# Altium schematic sheet ADC_I.SchDoc
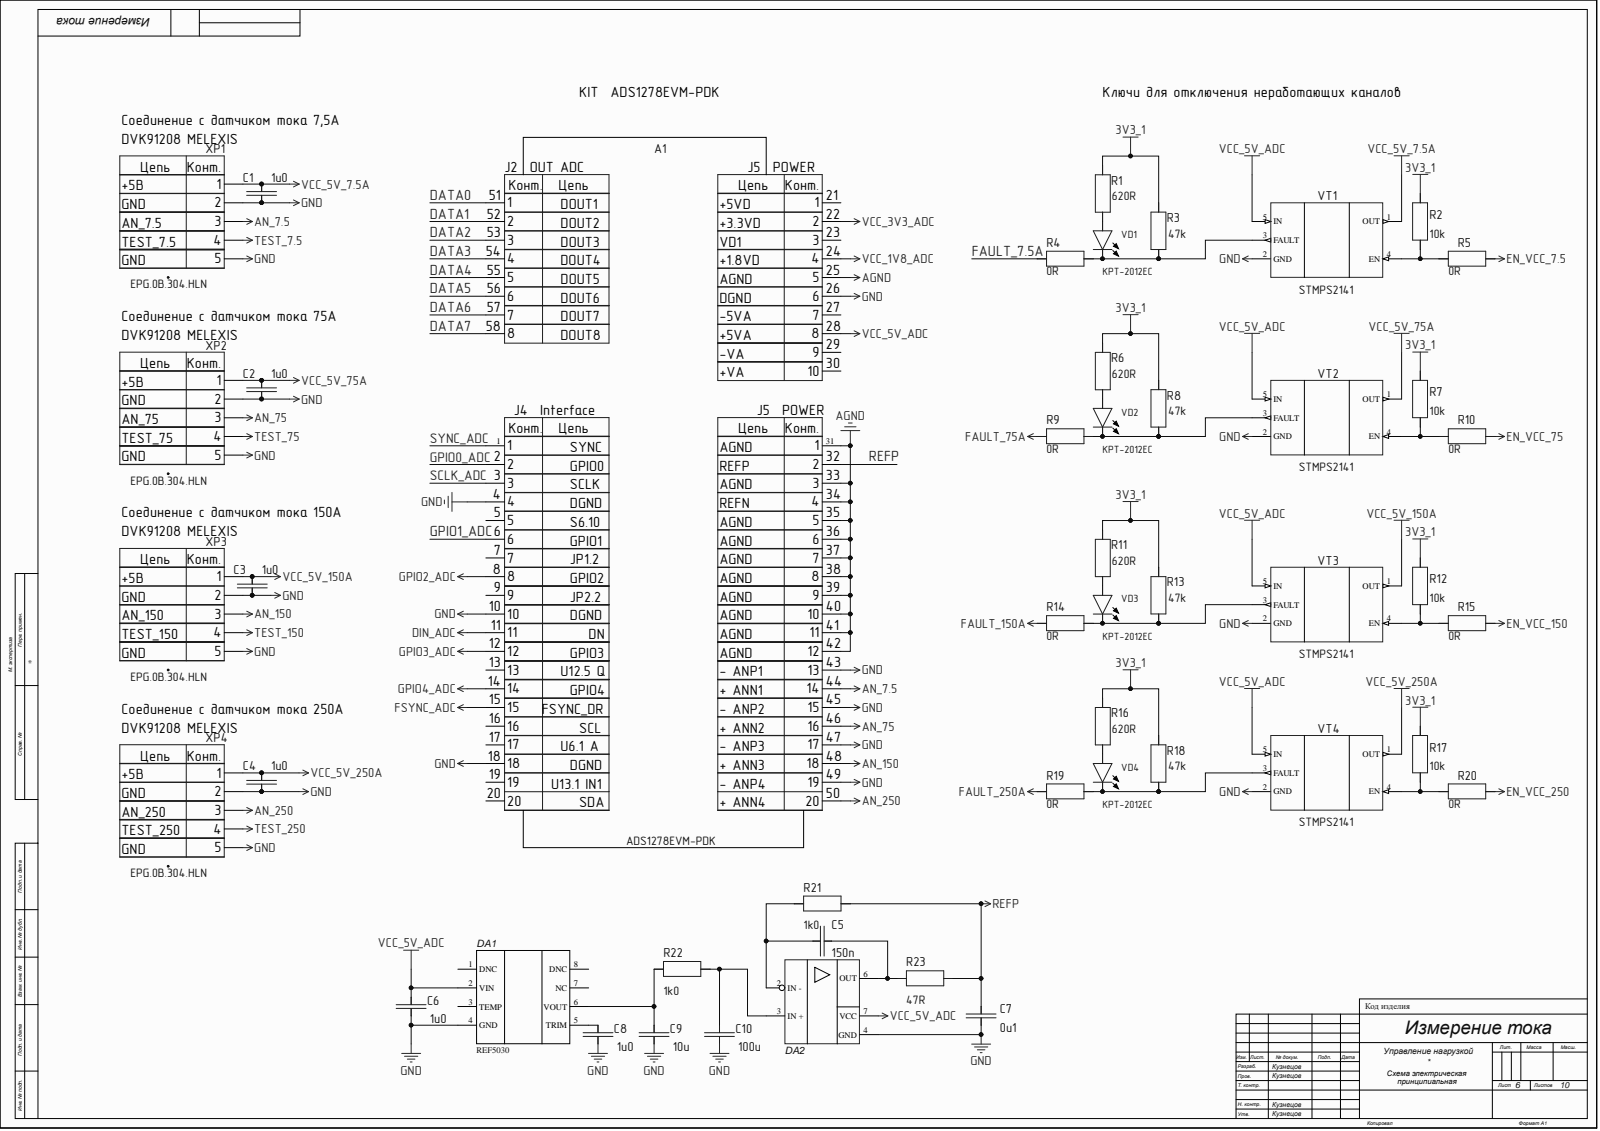
[diagram p0: ADC_I.SchDoc]

## Parts
A1  ADS1278EVM-PDK  [ADS1278EVM-PDK]
C1  1u0
C2  1u0
C3  1u0
C4  1u0
C5  150n
C6  1u0
C7  0u1
C8  1u0
C9  10u
C10  100u
DA1  REF5030  [SO-8]
DA2  OPA350  [SO-8]  (value not drawn)
R1  620R  [0805]
R2  10k  [0805]
R3  47k  [0805]
R4  0R  [0805]
R5  0R  [0805]
R6  620R  [0805]
R7  10k  [0805]
R8  47k  [0805]
R9  0R  [0805]
R10  0R  [0805]
R11  620R  [0805]
R12  10k  [0805]
R13  47k  [0805]
R14  0R  [0805]
R15  0R  [0805]
R16  620R  [0805]
R17  10k  [0805]
R18  47k  [0805]
R19  0R  [0805]
R20  0R  [0805]
R21  1k0  [0805]
R22  1k0  [0805]
R23  47R  [0805]
VD1  KPT-2012EC  [0805_LED_RED]
VD2  KPT-2012EC  [0805_LED_RED]
VD3  KPT-2012EC  [0805_LED_RED]
VD4  KPT-2012EC  [0805_LED_RED]
VT1  STMPS2141  [SOT-23-5]
VT2  STMPS2141  [SOT-23-5]
VT3  STMPS2141  [SOT-23-5]
VT4  STMPS2141  [SOT-23-5]
XP1  EPG.0B.304.HLN  [LEMO_EPG.0B.304.HLN]
XP2  EPG.0B.304.HLN  [LEMO_EPG.0B.304.HLN]
XP3  EPG.0B.304.HLN  [LEMO_EPG.0B.304.HLN]
XP4  EPG.0B.304.HLN  [LEMO_EPG.0B.304.HLN]

## Nets
3V3_1: R1.2 R2.1 R3.1 R6.2 R7.1 R8.1 R11.2 R12.1 R13.1 R16.2 R17.1 R18.1
AGND: A1.25 A1.31 A1.33 A1.34 A1.35 A1.36 A1.37 A1.38 A1.39 A1.40 A1.41 A1.42
AN_7.5: A1.44 XP1.3
AN_75: A1.46 XP2.3
AN_150: A1.48 XP3.3
AN_250: A1.50 XP4.3
DIN_ADC: A1.11
EN_VCC_7.5: R5.1
EN_VCC_75: R10.1
EN_VCC_150: R15.1
EN_VCC_250: R20.1
FAULT_75A: R9.2
FAULT_150A: R14.2
FAULT_250A: R19.2
FSYNC_ADC: A1.15
GND: A1.4 A1.10 A1.18 A1.26 A1.43 A1.45 A1.47 A1.49 C1.1 C2.1 C3.1 C4.1 C6.1 C7.1 C8.1 C9.1 C10.1 DA1.4(GND) DA2.4(GND) VT1.2(GND) VT2.2(GND) VT3.2(GND) VT4.2(GND) XP1.2 XP1.5 XP2.2 XP2.5 XP3.2 XP3.5 XP4.2 XP4.5
GPIO2_ADC: A1.8
GPIO3_ADC: A1.12
GPIO4_ADC: A1.14
TEST_7.5: XP1.4
TEST_75: XP2.4
TEST_150: XP3.4
TEST_250: XP4.4
VCC_1V8_ADC: A1.24
VCC_3V3_ADC: A1.22
VCC_5V_7.5A: C1.2 VT1.1(OUT) XP1.1
VCC_5V_75A: C2.2 VT2.1(OUT) XP2.1
VCC_5V_150A: C3.2 VT3.1(OUT) XP3.1
VCC_5V_250A: C4.2 VT4.1(OUT) XP4.1
VCC_5V_ADC: A1.28 C6.2 DA1.2(VIN) DA2.7(VCC) VT1.5(IN) VT2.5(IN) VT3.5(IN) VT4.5(IN)
DATA0: A1.51
DATA1: A1.52
DATA2: A1.53
DATA3: A1.54
DATA4: A1.55
DATA5: A1.56
DATA6: A1.57
DATA7: A1.58
FAULT_7.5A: R4.2
GPIO0_ADC: A1.2
GPIO1_ADC: A1.6
REFP: A1.32 C7.2 R21.1 R23.1
SCLK_ADC: A1.3
SYNC_ADC: A1.1
NetC5_1: C5.1 DA2.6(OUT) R23.2
NetC5_2: C5.2 DA2.2(IN_-) R21.2
NetC8_2: C8.2 DA1.5(TRIM)
NetC9_2: C9.2 DA1.6(VOUT) R22.2
NetC10_2: C10.2 DA2.3(IN_+) R22.1
NetR1_1: R1.1 VD1.1
NetR2_2: R2.2 R5.2 VT1.4(EN)
NetR3_2: R3.2 R4.1 VD1.2 VT1.3(FAULT)
NetR6_1: R6.1 VD2.1
NetR7_2: R7.2 R10.2 VT2.4(EN)
NetR8_2: R8.2 R9.1 VD2.2 VT2.3(FAULT)
NetR11_1: R11.1 VD3.1
NetR12_2: R12.2 R15.2 VT3.4(EN)
NetR13_2: R13.2 R14.1 VD3.2 VT3.3(FAULT)
NetR16_1: R16.1 VD4.1
NetR17_2: R17.2 R20.2 VT4.4(EN)
NetR18_2: R18.2 R19.1 VD4.2 VT4.3(FAULT)

Not connected: A1 5 7 9 13 16 17 19 20 21 23 27 29 30; DA1 1(DNC) 3(TEMP) 7(NC) 8(DNC)

## Library data of the parts
A1 = ADS1278EVM-PDK: KIT; Manufacturer: Texas Instruments; Component Kind: Standard; ComponentLink1Description: Параметры; ComponentLink2Description: Сайт производителя; ManufacturerPartNumber: ADS1278EVM-PDK; Author: Eleman; Library Name: Eleman_Plc.SchLib
C1-C8 = (no symbol name): Capacitor; Power\Voltage: 25V; TKE: 5XR; Tolerance: 10%; Корпус: 0805; Component Kind: Standard; ComponentLink1Description: Параметры; ComponentLink2Description: Сайт производителя; Author: Eleman; Library Name: eleman_capacitor.schlib
  C1-C4 C6 C8: Value: 1u0
  C5: Value: 150n
  C7: Value: 0u1
C9 = (no symbol name): Capacitor; Power\Voltage: 10V; TKE: 5XR; Tolerance: 10%; Корпус: 0805; Component Kind: Standard; ComponentLink1Description: Параметры; ComponentLink2Description: Сайт производителя; Value: 10u; Author: Eleman; Library Name: eleman_capacitor.schlib
C10 = (no symbol name): Capacitor; ManufacturerPartNumber: LMK325BJ107MM-T; Power\Voltage: 10V; TKE: 5XR; Tolerance: 20%; Корпус: 0805; Component Kind: Standard; ComponentLink1Description: Параметры; ComponentLink2Description: Сайт производителя; Value: 100u; Author: Eleman; Library Name: eleman_capacitor.schlib
DA1 = REF5030: Источник питания; Manufacturer: Texas Instruments; ManufacturerPartNumber: REF5030AID; Power\Voltage: 3V 10mA; TKE: 8ppm/C; Tolerance: 0.1%; Корпус: SOIC-8; Component Kind: Standard; ComponentLink1Description: Параметры; ComponentLink2Description: Сайт производителя; Author: Eleman; Library Name: Eleman_Power.SchLib
DA2 = OPA350: Операционный усилитель; Manufacturer: Texas Instruments; ManufacturerPartNumber: OPA350UA; Корпус: SOIC-8; Component Kind: Standard; ComponentLink1Description: Параметры; ComponentLink2Description: Сайт производителя; Author: Eleman; ComponentLink1URL: C:\Demo\Datasheet\MAX6816-MAX6818.pdf; Library Name: Eleman_Amplifier.SchLib
R1-R23 = R0805: Импортный постоянный углеродистый резистор; Manufacturer: Vishay Dale; Power\Voltage: 0.125W; Tolerance: 5%; Component Kind: Standard; ComponentLink1Description: Параметры; ComponentLink2Description: Сайт производителя; ManufacturerPartNumber: R0805; Корпус: SMD 0805; Author: Eleman; Library Name: Eleman_Resistor.SchLib
  R1 R6 R11 R16: Value: 620R
  R2 R7 R12 R17: Value: 10k
  R3 R8 R13 R18: Value: 47k
  R4 R5 R9 R10 R14 R15 R19 R20: Value: 0R
  R21 R22: Value: 1k0
  R23: Value: 47R
VD1-VD4 = KPT-2012EC: Светодиод/Красный/Чип; Manufacturer: KINGBRIGHT; Power\Voltage: 20mA; Rem: Red; Component Kind: Standard; ComponentLink1Description: Параметры; ComponentLink2Description: Сайт производителя; ManufacturerPartNumber: KPT-2012EC; Value: KPT-2012EC; Корпус: SMD 0805; Author: Eleman; ComponentLink1URL: d:\YandexDisk\Project_Altium\Lib_Eleman\; Library Name: Eleman_Diods.SchLib
VT1-VT4 = STMPS2141: Транзистор; ManufacturerPartNumber: STMPS2141STR; Корпус: SO-23-5; Component Kind: Standard; ComponentLink1Description: Параметры; ComponentLink2Description: Сайт производителя; Author: Eleman; Library Name: Eleman_Driver.SchLib
XP1-XP4 = EPG.0B.304.HLN: Разъем; Manufacturer: LEMO; Корпус: 4 pin; Component Kind: Standard; ComponentLink1Description: Параметры; ComponentLink2Description: Сайт производителя; ManufacturerPartNumber: EPG.0B.304.HLN; Author: Eleman; ComponentLink1URL: C:\Demo\Datasheet; Library Name: Eleman_Connector.SchLib
